# Altium schematic sheet BC26_OPEN_MODULE.SchDoc(BC26_OPEN_MODULE)
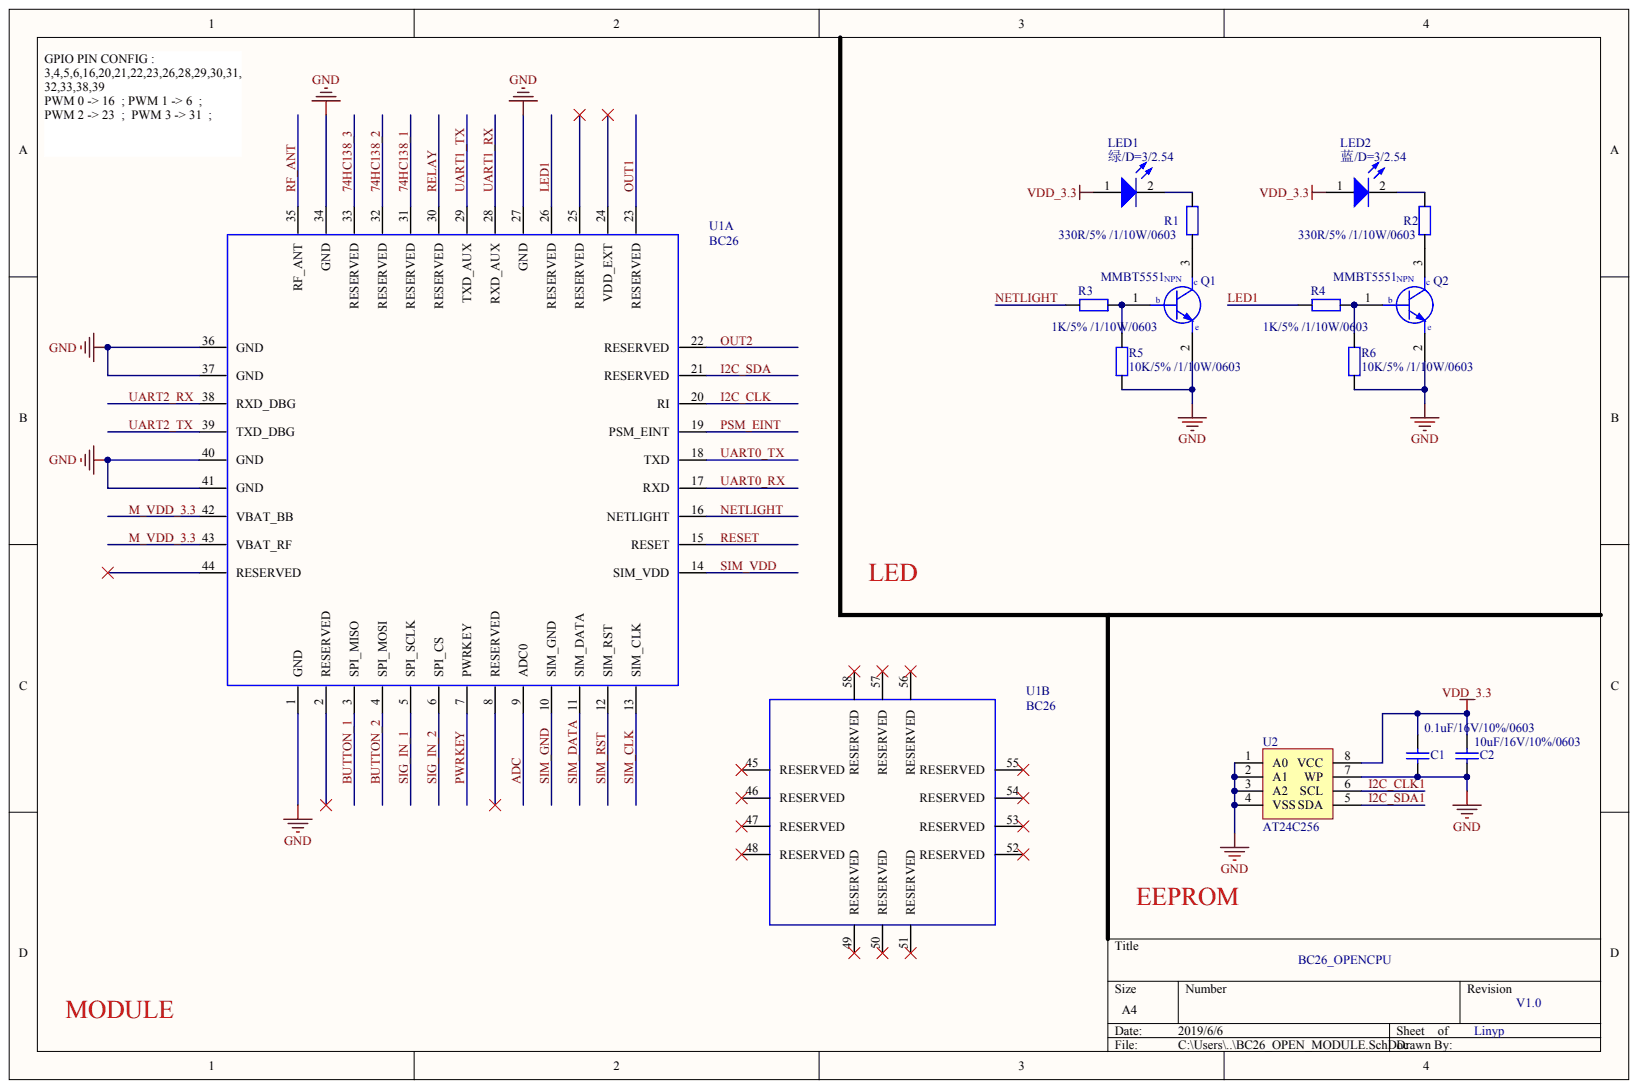
[diagram p0: BC26_OPEN_MODULE.SchDoc]

## Parts
C1  0.1uF/16V/10%/0603
C2  10uF/16V/10%/0603
LED1  绿/D=3/2.54
LED2  蓝/D=3/2.54
Q1  MMBT5551
Q2  MMBT5551
R1  330R/5% /1/10W/0603
R2  330R/5% /1/10W/0603
R3  1K/5% /1/10W/0603
R4  1K/5% /1/10W/0603
R5  10K/5% /1/10W/0603
R6  10K/5% /1/10W/0603
U1  BC26  (parts A,B)
U2  AT24C256

## Nets
GND: C1.1 C2.1 Q1.2 Q2.2 R5.1 R6.1 U1.1(GND) U1.27(GND) U1.34(GND) U1.36(GND) U1.37(GND) U1.40(GND) U1.41(GND) U2.1(A0) U2.2(A1) U2.3(A2) U2.4(VSS) U2.7(WP)
VDD_3.3: C1.2 C2.2 LED1.1 LED2.1 U2.8(VCC)
74HC138_1: U1.31(RESERVED)
74HC138_2: U1.32(RESERVED)
74HC138_3: U1.33(RESERVED)
ADC: U1.9(ADC0)
BUTTON_1: U1.3(SPI_MISO)
BUTTON_2: U1.4(SPI_MOSI)
I2C_CLK: U1.20(RI)
I2C_CLK1: U2.6(SCL)
I2C_SDA: U1.21(RESERVED)
I2C_SDA1: U2.5(SDA)
LED1: R4.1 U1.26(RESERVED)
M_VDD_3.3: U1.42(VBAT_BB) U1.43(VBAT_RF)
NETLIGHT: R3.2 U1.16(NETLIGHT)
OUT1: U1.23(RESERVED)
OUT2: U1.22(RESERVED)
PSM_EINT: U1.19(PSM_EINT)
PWRKEY: U1.7(PWRKEY)
RELAY: U1.30(RESERVED)
RESET: U1.15(RESET)
RF_ANT: U1.35(RF_ANT)
SIG_IN_1: U1.5(SPI_SCLK)
SIG_IN_2: U1.6(SPI_CS)
SIM_CLK: U1.13(SIM_CLK)
SIM_DATA: U1.11(SIM_DATA)
SIM_GND: U1.10(SIM_GND)
SIM_RST: U1.12(SIM_RST)
SIM_VDD: U1.14(SIM_VDD)
UART0_RX: U1.17(RXD)
UART0_TX: U1.18(TXD)
UART1_RX: U1.28(RXD_AUX)
UART1_TX: U1.29(TXD_AUX)
UART2_RX: U1.38(RXD_DBG)
UART2_TX: U1.39(TXD_DBG)
NetLED1_2: LED1.2 R1.2
NetLED2_2: LED2.2 R2.2
NetQ1_1: Q1.1 R3.1 R5.2
NetQ1_3: Q1.3 R1.1
NetQ2_1: Q2.1 R4.2 R6.2
NetQ2_3: Q2.3 R2.1

Not connected: U1 2(RESERVED) 8(RESERVED) 24(VDD_EXT) 25(RESERVED) 44(RESERVED) 45(RESERVED) 46(RESERVED) 47(RESERVED) 48(RESERVED) 49(RESERVED) 50(RESERVED) 51(RESERVED) 52(RESERVED) 53(RESERVED) 54(RESERVED) 55(RESERVED) 56(RESERVED) 57(RESERVED) 58(RESERVED)

## Library data of the parts
C1 C2 LED1 LED2 Q1 Q2 R1-R6 U1 U2 = (no symbol name)
  C1 C2: Published: 8-Jun-2000; LatestRevisionDate: 17-Jul-2002; LatestRevisionNote: Re-released for DXP Platform.; PackageReference: RAD-0.3
  LED1 LED2: Published: 8-Jun-2000; LatestRevisionDate: 08-Jul-2005; LatestRevisionNote: Mech Layer 1 removed.; PackageReference: LED-0
  U1: Part Description: *; Value: *; Geometry.Height: *; Manufacturer: *; PCB DECAL: *
  C1 C2 LED1 LED2: Publisher: Altium Limited
